# Altium schematic sheet controller_master.SchDoc(controller_master)
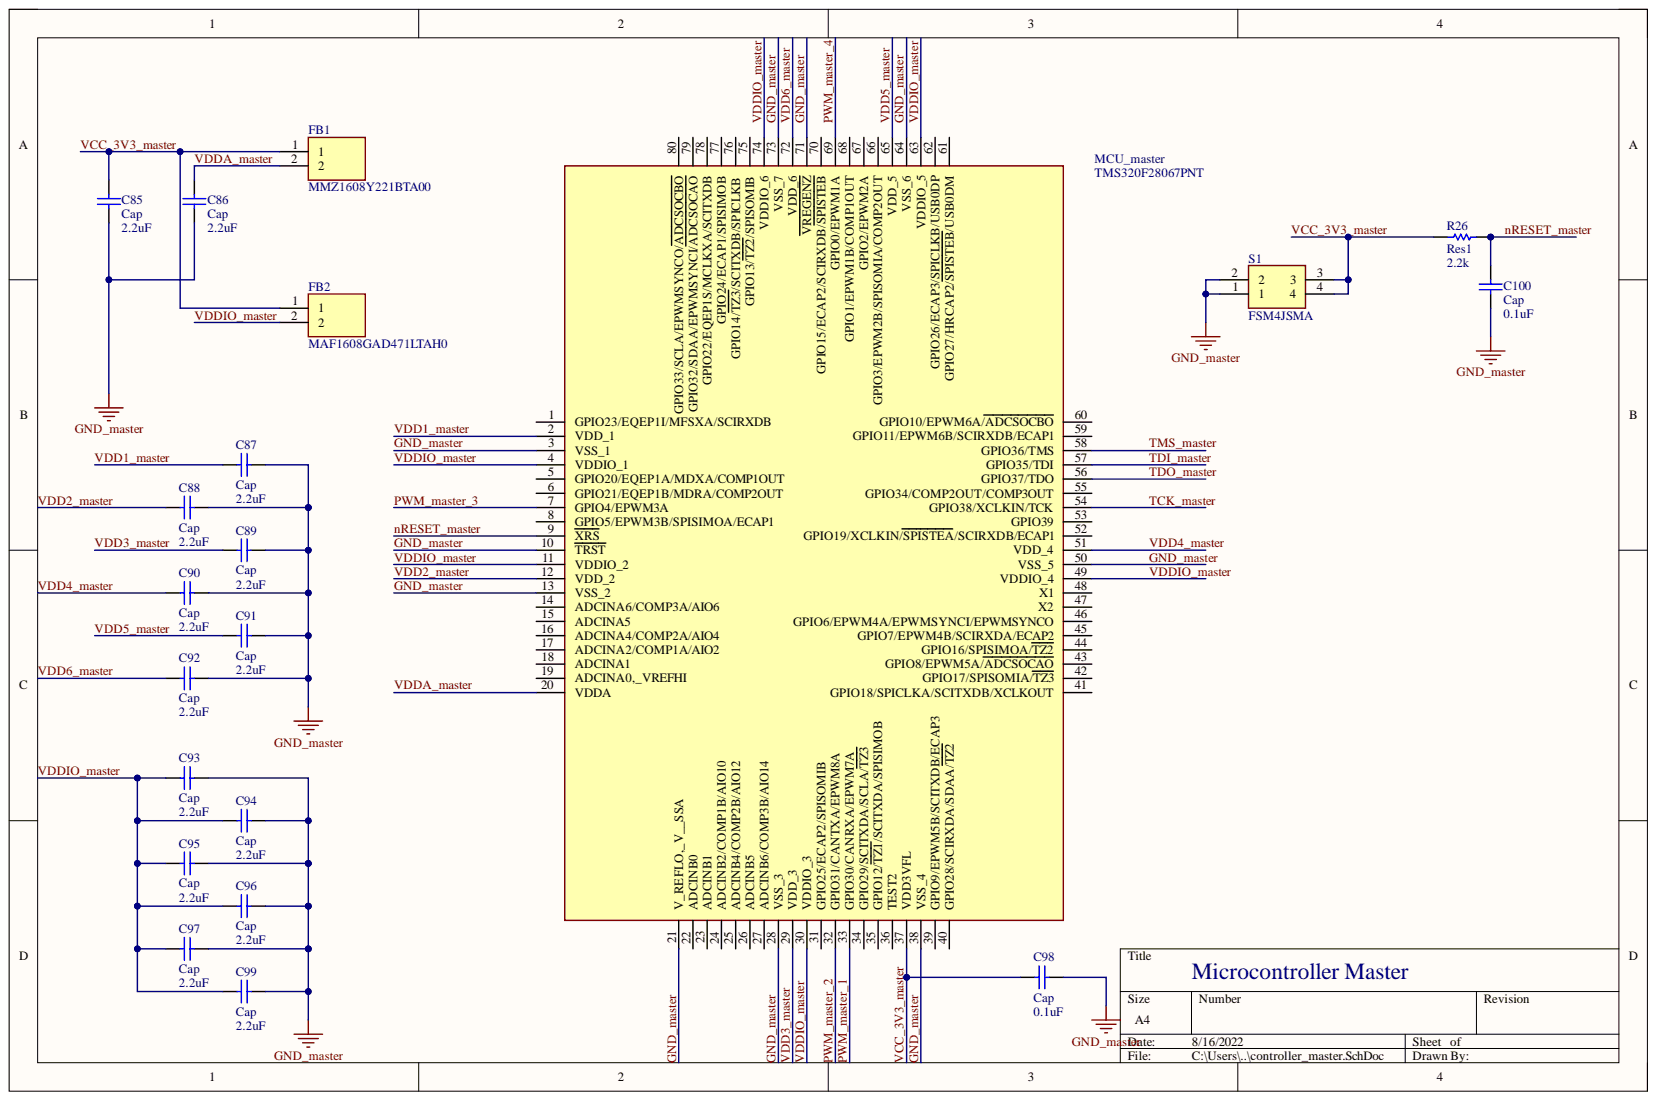
[diagram p0: controller_master.SchDoc]

## Parts
C85  Cap  value 2.2uF  [C0805]
C86  Cap  value 2.2uF  [C0805]
C87  Cap  value 2.2uF  [C0805]
C88  Cap  [C0805]
C89  Cap  value 2.2uF  [C0805]
C90  Cap  value 2.2uF  [C0805]
C91  Cap  value 2.2uF  [C0805]
C92  Cap  value 2.2uF  [C0805]
C93  Cap  value 2.2uF  [C0805]
C94  Cap  value 2.2uF  [C0805]
C95  Cap  value 2.2uF  [C0805]
C96  Cap  value 2.2uF  [C0805]
C97  Cap  value 2.2uF  [C0805]
C98  Cap  value 0.1uF  [C0805]
C99  Cap  value 2.2uF  [C0805]
C100  Cap  value 0.1uF  [C0805]
FB1  MMZ1608Y221BTA00  [BEADC1608X95N]
FB2  MAF1608GAD471LTAH0  [BEADC1608X75N]
MCU_master  TMS320F28067PNT  [QFP50P1400X1400X160-80N]
R26  Res1  value 2.2k  [RES0805W]
S1  FSM4JSMA  [FSM4JSMA]

## Nets
GND_master: C85.2 C86.2 C87.1 C88.1 C89.1 C90.1 C91.1 C92.1 C93.1 C94.1 C95.1 C96.1 C97.1 C98.1 C99.1 C100.2 MCU_master.3(VSS_1) MCU_master.10(TRST) MCU_master.13(VSS_2) MCU_master.21(V_REFLO,_V__SSA) MCU_master.28(VSS_3) MCU_master.38(VSS_4) MCU_master.50(VSS_5) MCU_master.64(VSS_6) MCU_master.71(VREGENZ) MCU_master.73(VSS_7) S1.1 S1.2
nRESET_master: C100.1 MCU_master.9(XRS) R26.2
PWM_master_1: MCU_master.33(GPIO30/CANRXA/EPWM7A)
PWM_master_2: MCU_master.32(GPIO31/CANTXA/EPWM8A)
PWM_master_3: MCU_master.7(GPIO4/EPWM3A)
PWM_master_4: MCU_master.69(GPIO0/EPWM1A)
TCK_master: MCU_master.54(GPIO38/XCLKIN/TCK)
TDI_master: MCU_master.57(GPIO35/TDI)
TDO_master: MCU_master.56(GPIO37/TDO)
TMS_master: MCU_master.58(GPIO36/TMS)
VCC_3V3_master: C85.1 C98.2 FB1.1 FB2.1 MCU_master.37(VDD3VFL) R26.1 S1.3 S1.4
VDD1_master: C87.2 MCU_master.2(VDD_1)
VDD2_master: C88.2 MCU_master.12(VDD_2)
VDD3_master: C89.2 MCU_master.29(VDD_3)
VDD4_master: C90.2 MCU_master.51(VDD_4)
VDD5_master: C91.2 MCU_master.65(VDD_5)
VDD6_master: C92.2 MCU_master.72(VDD_6)
VDDA_master: C86.1 FB1.2 MCU_master.20(VDDA)
VDDIO_master: C93.2 C94.2 C95.2 C96.2 C97.2 C99.2 FB2.2 MCU_master.4(VDDIO_1) MCU_master.11(VDDIO_2) MCU_master.30(VDDIO_3) MCU_master.49(VDDIO_4) MCU_master.63(VDDIO_5) MCU_master.74(VDDIO_6)

Not connected: MCU_master 1(GPIO23/EQEP1I/MFSXA/SCIRXDB) 5(GPIO20/EQEP1A/MDXA/COMP1OUT) 6(GPIO21/EQEP1B/MDRA/COMP2OUT) 8(GPIO5/EPWM3B/SPISIMOA/ECAP1) 14(ADCINA6/COMP3A/AIO6) 15(ADCINA5) 16(ADCINA4/COMP2A/AIO4) 17(ADCINA2/COMP1A/AIO2) 18(ADCINA1) 19(ADCINA0,_VREFHI) 22(ADCINB0) 23(ADCINB1) 24(ADCINB2/COMP1B/AIO10) 25(ADCINB4/COMP2B/AIO12) 26(ADCINB5) 27(ADCINB6/COMP3B/AIO14) 31(GPIO25/ECAP2/SPISOMIB) 34(GPIO29/SCITXDA/SCLA/TZ3) 35(GPIO12/TZ1/SCITXDA/SPISIMOB) 36(TEST2) 39(GPIO9/EPWM5B/SCITXDB/ECAP3) 40(GPIO28/SCIRXDA/SDAA/TZ2) 41(GPIO18/SPICLKA/SCITXDB/XCLKOUT) 42(GPIO17/SPISOMIA/TZ3) 43(GPIO8/EPWM5A/ADCSOCAO) 44(GPIO16/SPISIMOA/TZ2) 45(GPIO7/EPWM4B/SCIRXDA/ECAP2) 46(GPIO6/EPWM4A/EPWMSYNCI/EPWMSYNCO) 47(X2) 48(X1) 52(GPIO19/XCLKIN/SPISTEA/SCIRXDB/ECAP1) 53(GPIO39) 55(GPIO34/COMP2OUT/COMP3OUT) 59(GPIO11/EPWM6B/SCIRXDB/ECAP1) 60(GPIO10/EPWM6A/ADCSOCBO) 61(GPIO27/HRCAP2/SPISTEB/USB0DM) 62(GPIO26/ECAP3/SPICLKB/USB0DP) 66(GPIO3/EPWM2B/SPISOMIA/COMP2OUT) 67(GPIO2/EPWM2A) 68(GPIO1/EPWM1B/COMP1OUT) 70(GPIO15/ECAP2/SCIRXDB/SPISTEB) 75(GPIO13/TZ2/SPISOMIB) 76(GPIO14/TZ3/SCITXDB/SPICLKB) 77(GPIO24/ECAP1/SPISIMOB) 78(GPIO22/EQEP1S/MCLKXA/SCITXDB) 79(GPIO32/SDAA/EPWMSYNCI/ADCSOCAO) 80(GPIO33/SCLA/EPWMSYNCO/ADCSOCBO)

## Library data of the parts
C85-C87 C89 C91 C92 C94 C96 C98-C100 = Cap: Capacitor; Component Kind: Standard; LatestRevisionDate: 17-Jul-2002; LatestRevisionNote: Re-released for DXP Platform.; Library Name: Miscellaneous Devices.IntLib; PackageDescription: Radial Cap, Thru-Hole; 2 Leads; 0.3 in Pin Spacing; PackageReference: RAD-0.3; Published: 8-Jun-2000; Signal Integrity: Cap; Simulation: CAP; Publisher: Altium Limited
C88 C90 C93 C95 C97 = Cap: Capacitor; Value: 2.2uF; Component Kind: Standard; LatestRevisionDate: 17-Jul-2002; LatestRevisionNote: Re-released for DXP Platform.; Library Name: Miscellaneous Devices.IntLib; PackageDescription: Radial Cap, Thru-Hole; 2 Leads; 0.3 in Pin Spacing; PackageReference: RAD-0.3; Published: 8-Jun-2000; Signal Integrity: Cap; Simulation: CAP; Publisher: Altium Limited
FB1 = MMZ1608Y221BTA00: Ferrite Bead; Manufacturer_Name: TDK; Component Kind: Standard; Datasheet Link: https://product.tdk.com/system/files/dam/doc/product/emc/emc/beads/catalog/beads_commercial_signal_mmz1608_en.pdf; Height: 0.95mm; Manufacturer_Part_Number: MMZ1608Y221BTA00
FB2 = MAF1608GAD471LTAH0: Filter; Manufacturer_Name: TDK; Component Kind: Standard; Datasheet Link: https://componentsearchengine.com//MAF1608GAD471LTAH0.pdf; Height: 0.75mm; Manufacturer_Part_Number: MAF1608GAD471LTAH0; Mouser2 Part Number: 810-MAF1608GAD471LTA; Mouser2 Price/Stock: https://www.mouser.com/Search/Refine.aspx?Keyword=810-MAF1608GAD471LTA
MCU_master = TMS320F28067PNT: Integrated Circuit; Manufacturer_Name: Texas Instruments; Component Kind: Standard; Datasheet Link: http://www.ti.com/lit/gpn/TMS320F28067; Height: 1.6mm; Manufacturer_Part_Number: TMS320F28067PNT
R26 = Res1: Resistor; Value: 2.2k; Component Kind: Standard; LatestRevisionDate: 17-Jul-2002; LatestRevisionNote: Re-released for DXP Platform.; Library Name: Miscellaneous Devices.IntLib; PackageDescription: Axial Device, Thru-Hole; 2 Leads; 0.3 in Pin Spacing; PackageReference: AXIAL-0.3; Published: 8-Jun-2000; Signal Integrity: Res; Simulation: RESISTOR; Publisher: Altium Limited
S1 = FSM4JSMA: Switch; Manufacturer_Name: TE Connectivity; Component Kind: Standard; Datasheet Link: https://componentsearchengine.com//FSM4JSMA.pdf; Height: 5.25mm; Library Name: FSM4JSMA.SchLib; Manufacturer_Part_Number: FSM4JSMA; Mouser2 Part Number: 506-FSM4JSMA; Mouser2 Price/Stock: https://www.mouser.com/Search/Refine.aspx?Keyword=506-FSM4JSMA
